# Altium schematic sheet Relay_IO.SchDoc
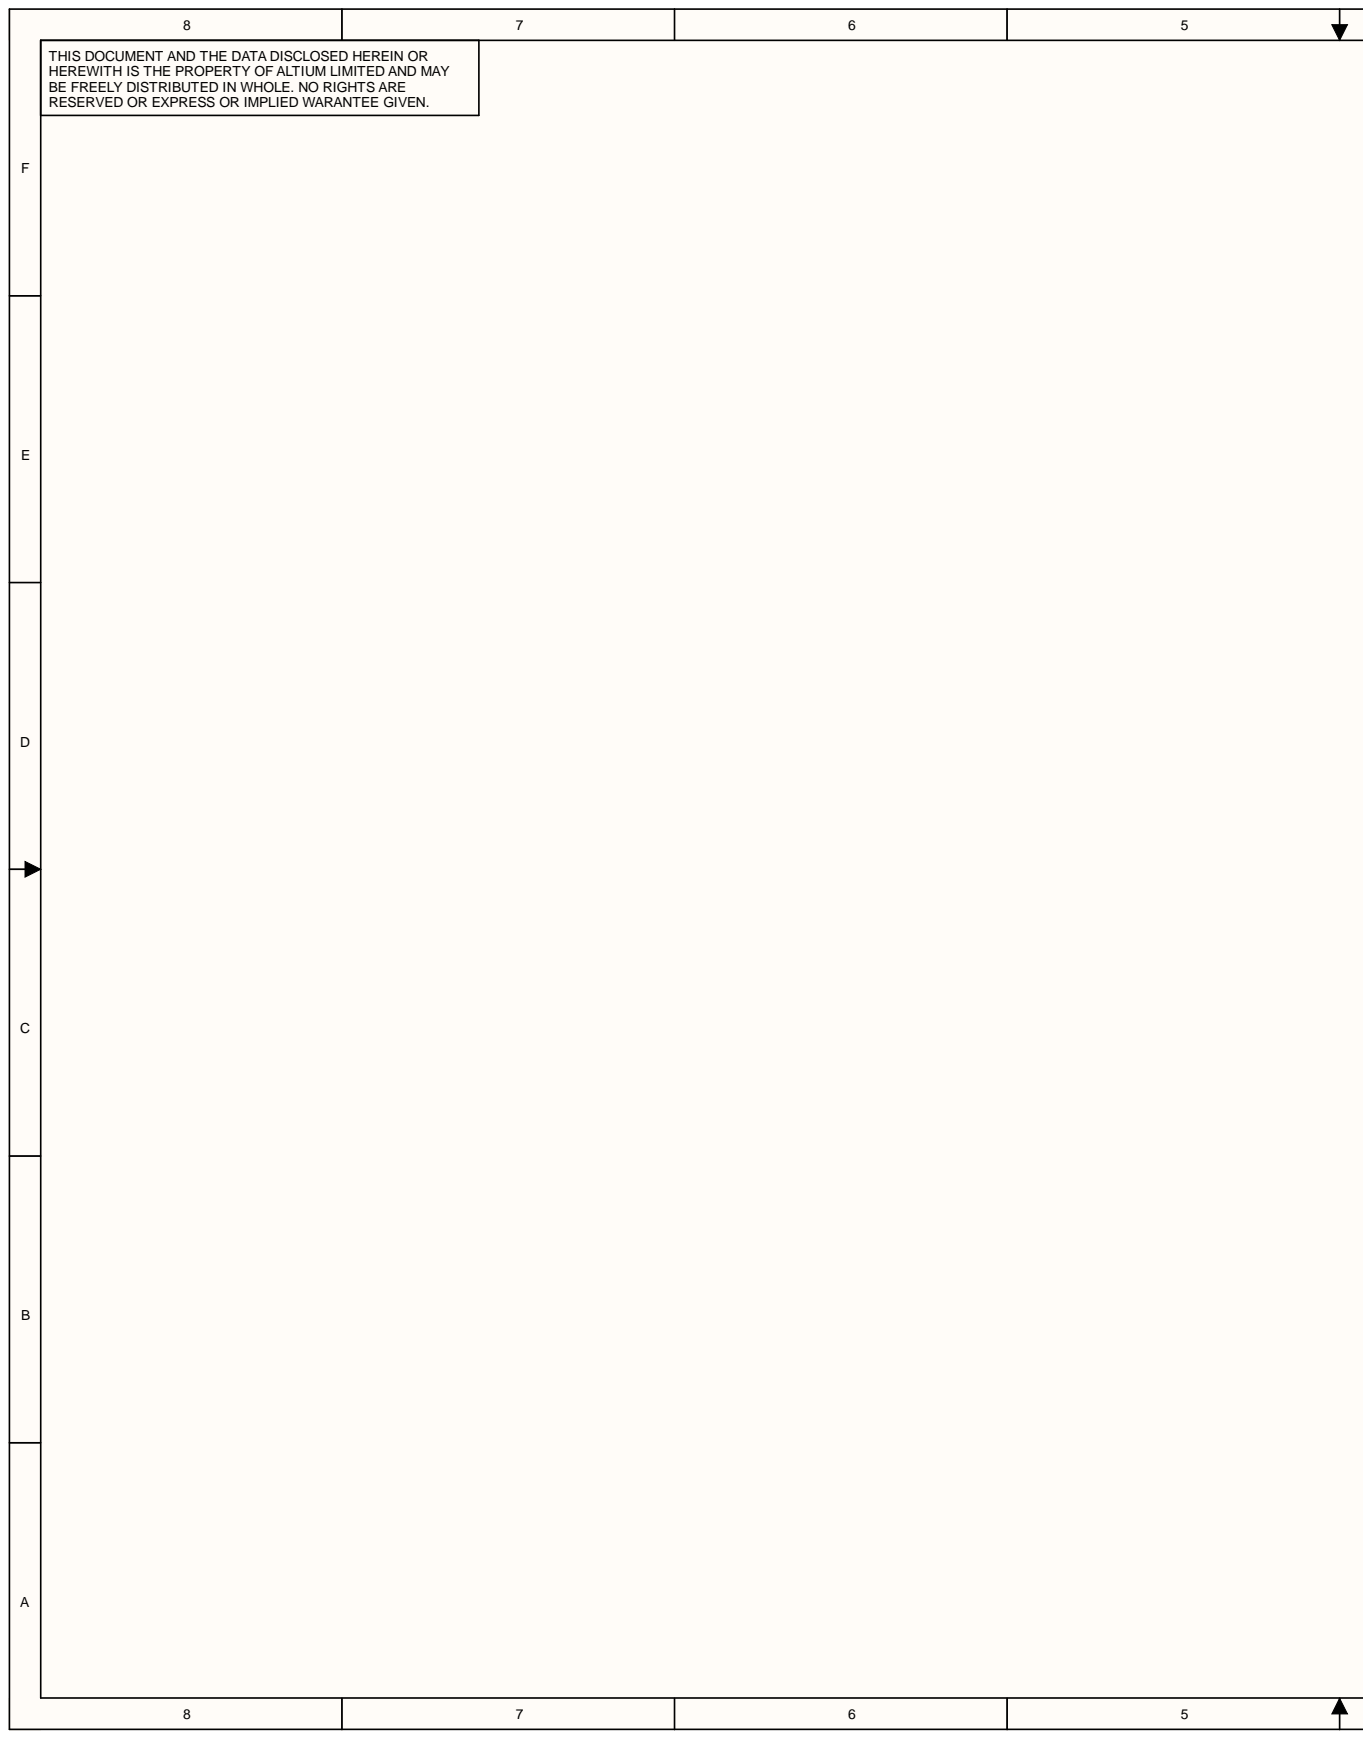
[diagram p0: Relay_IO.SchDoc, left]
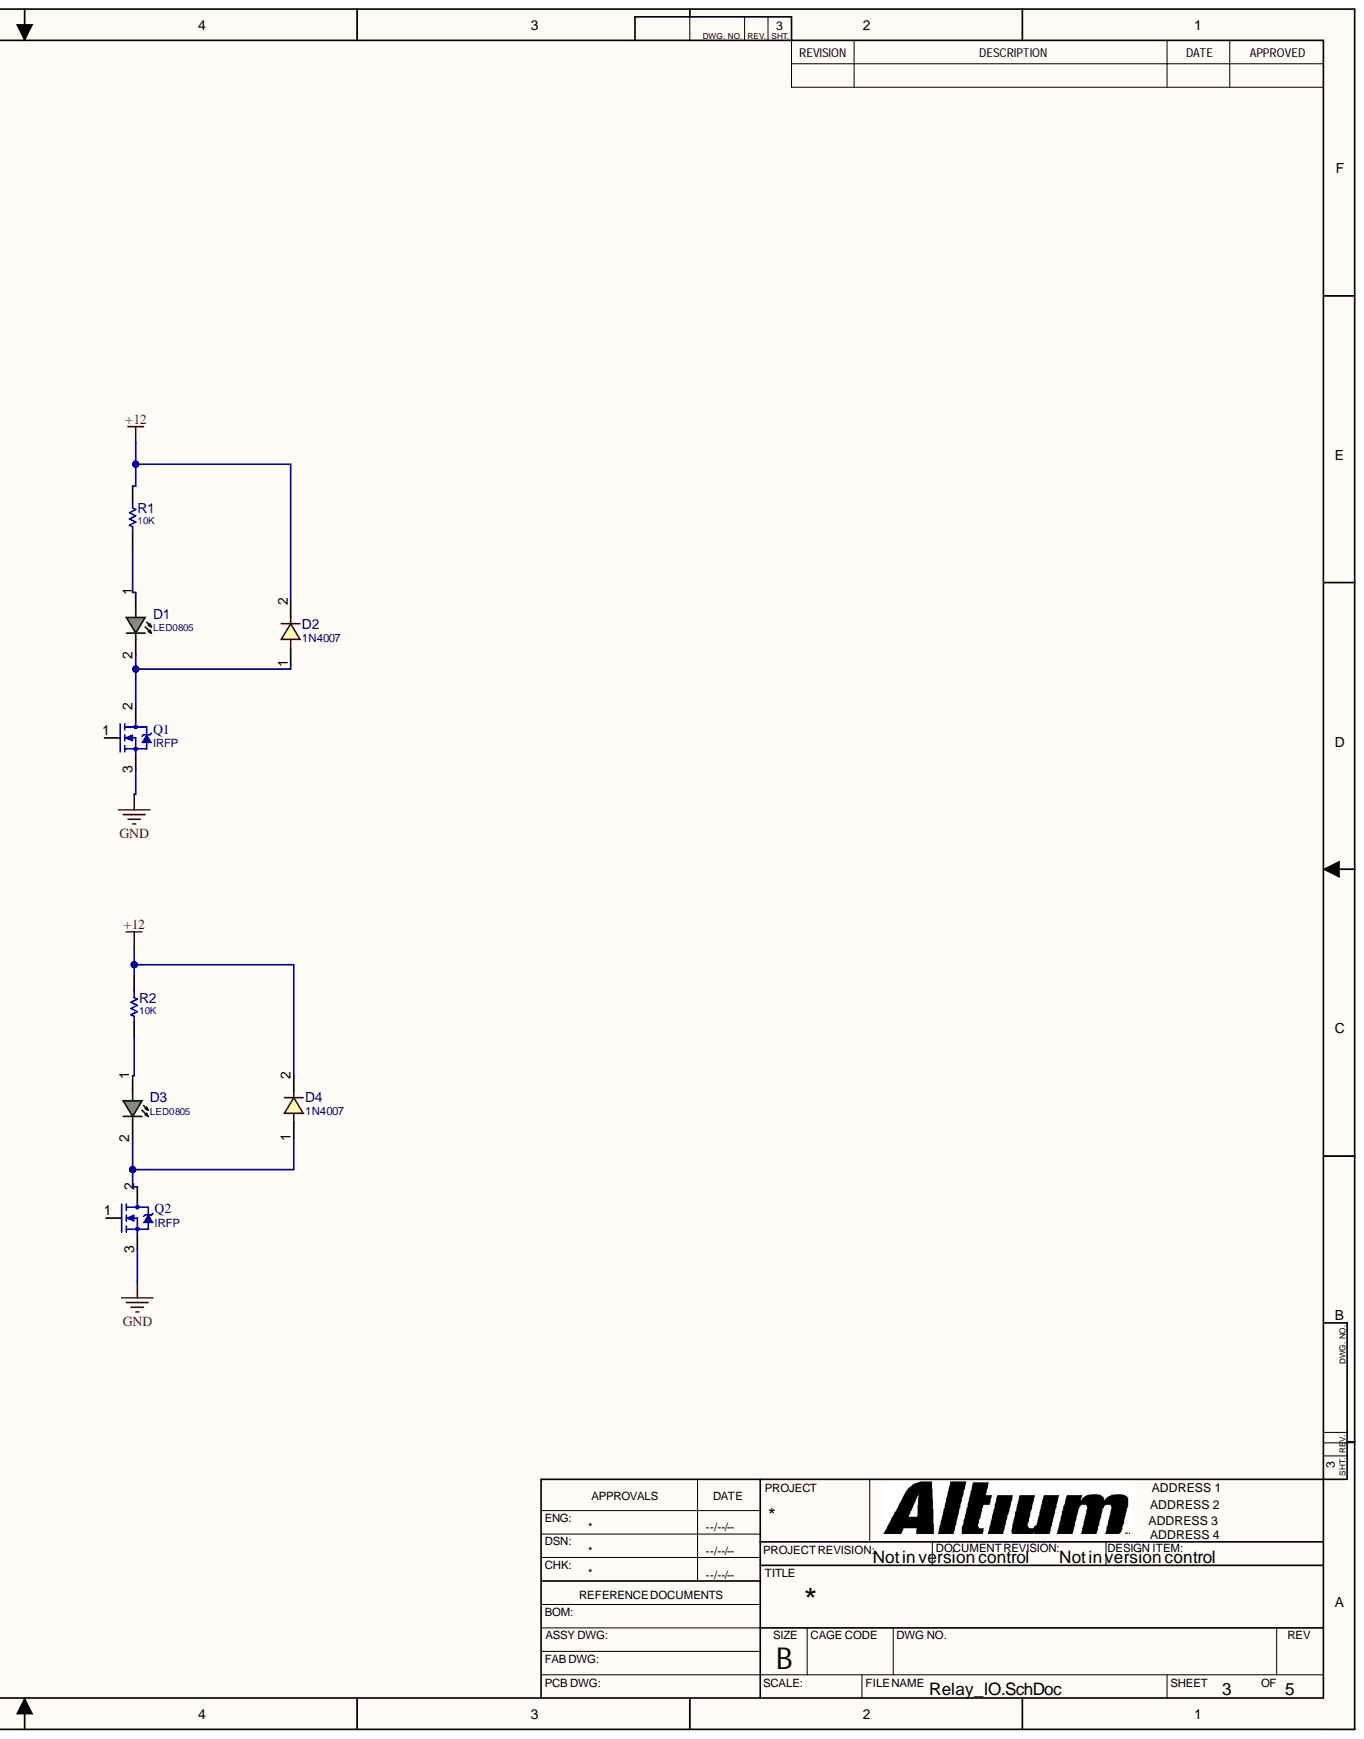
[diagram p1: Relay_IO.SchDoc, right]

## Parts
D1  LED0805  [LED0805]
D2  1N4007  [D_SMA]
D3  LED0805  [LED0805]
D4  1N4007  [D_SMA]
Q1  IRFP  [TO247_3]
Q2  IRFP  [TO247_3]
R1  10K  [R0805]
R2  10K  [R0805]

## Nets
+12: D2.2 D4.2 R1.2 R2.2
GND: Q1.3 Q2.3
NetD1_1: D1.1 R1.1
NetD1_2: D1.2 D2.1 Q1.2
NetD3_1: D3.1 R2.1
NetD3_2: D3.2 D4.1 Q2.2

Not connected: Q1 1; Q2 1

## Library data of the parts
D1 D3 = LED_SMD_0805: LED, SMD, 0805; DESC: DESCRIPTION; MANF_P#: MANF_PART#; PARAM2: 2.2V; ASSEMBLY: ASSEMBLY; Component Kind: Standard; Library Name: led(diode).SchLib; MANF: MANF; PRICE: PRICE; VALUE: LED0805
D2 D4 = DIODE_SMD_1N4007: DIODE, GENERAL PURPOSE, 1000V/1A; DESC: DESCRIPTION; MANF: Kingtronics; MANF_P#: M7; PARAM: 1000V VRRM; PARAM2: 1A; ASSEMBLY: ASSEMBLY; Component Kind: Standard; Library Name: diode.SchLib; PRICE: PRICE; VALUE: 1N4007
Q1 Q2 = MOSN_IRFP: ASSEMBLY: ASSEMBLY; Component Kind: Standard; Library Name: transistor_fet.SchLib; MANF_P#: IRFP; VALUE: IRFP
R1 R2 = RES_SMD_0805: RESISTOR, SMD; DESC: DESCRIPTION; DIST#: MOUSER; PARAM: -W; PARAM2: 1%; ASSEMBLY: ASSEMBLY; Component Kind: Standard; Library Name: passive_smd.SchLib; MANF: -; MANF_P#: -; PRICE: 100= 0.5$; VALUE: 10K
